# Altium schematic sheet Power_2A_5Vto4VTI.SchDoc
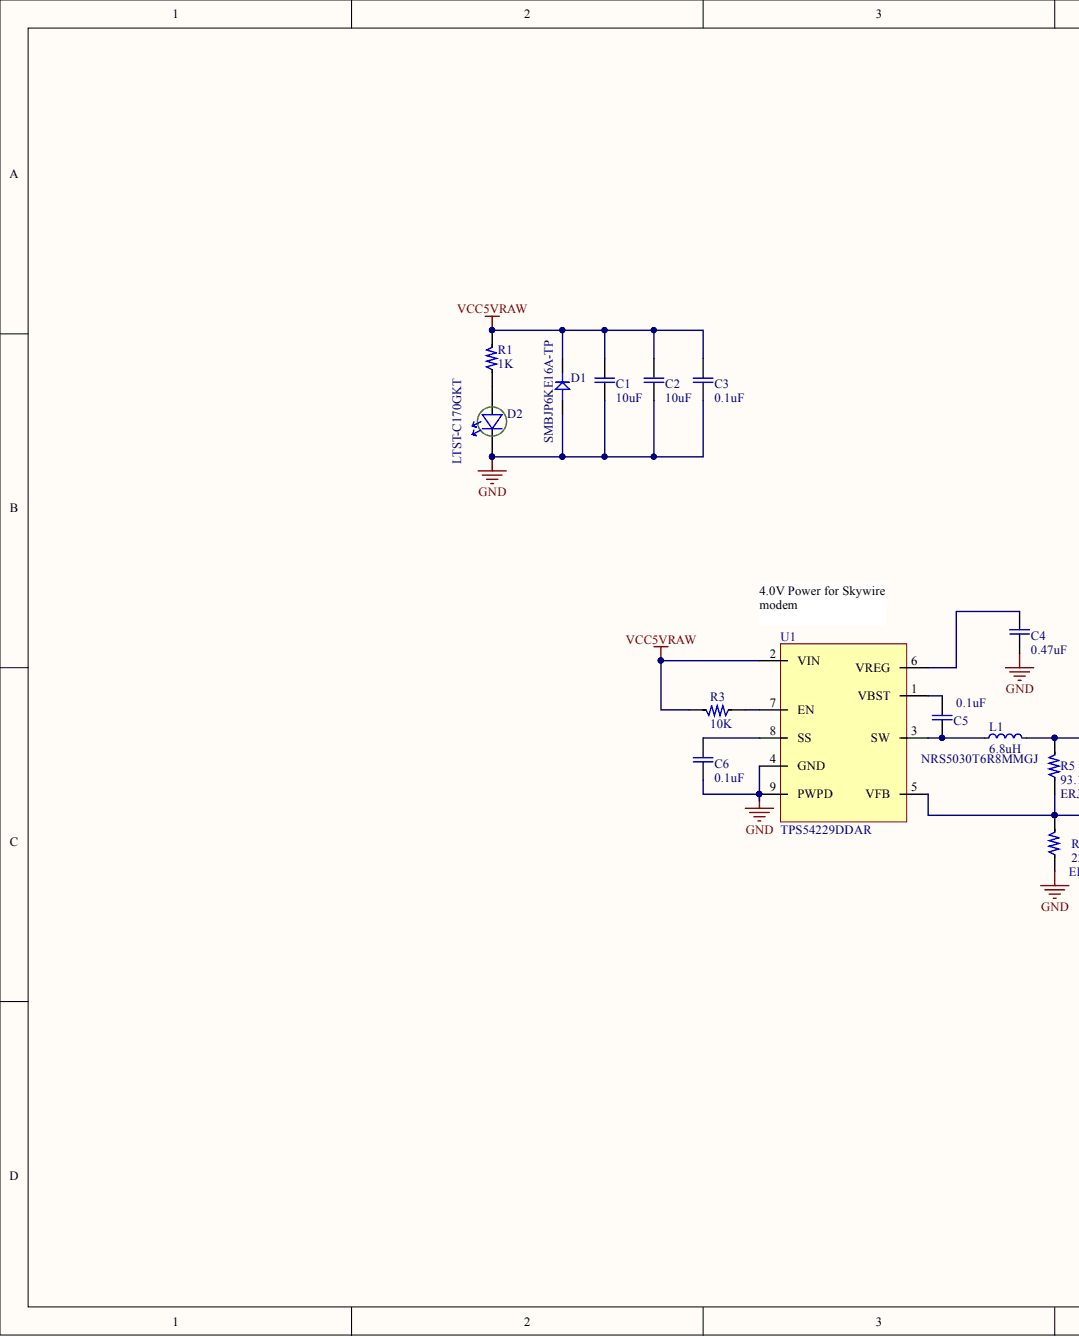
[diagram p0: Power_2A_5Vto4VTI.SchDoc, left]
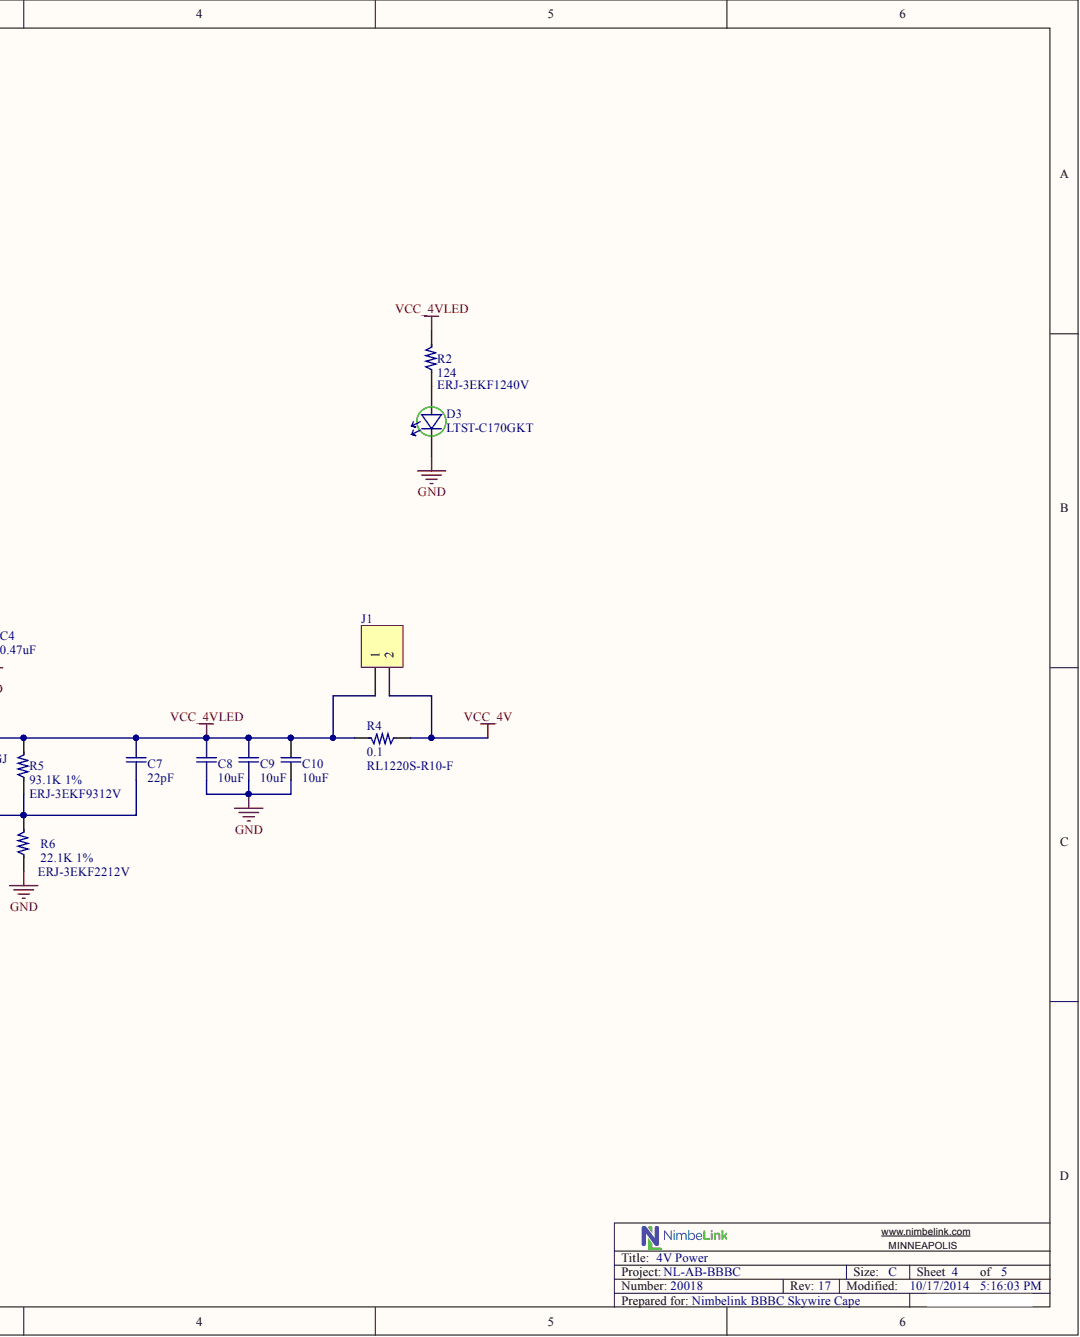
[diagram p1: Power_2A_5Vto4VTI.SchDoc, right]

## Parts
C1  10uF  [0603]
C2  10uF  [0603]
C3  0.1uF  [0603]
C4  0.47uF  [0603]
C5  0.1uF  [0603]
C6  0.1uF  [0603]
C7  22pF  [0603]
C8  10uF  [0603]
C9  10uF  [0603]
C10  10uF  [0603]
D1  Manufacturer Part Number 1: SMBJP6KE16A-TP  [D_SMBJP6KE16A-TP]  (hidden comment: D_TVS DIODE 13.6VWM 22.5VC SMBJ)
D2  Manufacturer Part Number 1: LTST-C170GKT  [D_LED_GREEN]  (hidden comment: D_LED_GREEN)
D3  Manufacturer Part Number 1: LTST-C170GKT  [D_LED_GREEN]  (hidden comment: D_LED_GREEN)
J1  NP  [HDR1X2]  (value not drawn)
L1  6.8uH  [NR5030]
R1  1K  [0603]
R2  124  Manufacturer Part Number 1: ERJ-3EKF1240V  [0603]
R3  10K  [0603]
R4  0.1  Manufacturer Part Number 2: RL1220S-R10-F  [0805]
R5  93.1K 1%  Manufacturer Part Number 1: ERJ-3EKF9312V  [0603]
R6  22.1K 1%  Manufacturer Part Number 1: ERJ-3EKF2212V  [0603]
U1  Manufacturer Part Number 1: TPS54229DDAR  [TPS54229DDA]  (hidden comment: U_TPS54229DDA)

## Nets
GND: C1.2 C2.2 C3.2 C4.1 C6.2 C8.2 C9.2 C10.2 D1.A D2.2 D3.2 R6.1 U1.4(GND) U1.9(PWPD)
VCC5VRAW: C1.1 C2.1 C3.1 D1.K R1.2 R3.2 U1.2(VIN)
VCC_4V: J1.2 R4.1
VCC_4VLED: C7.1 C8.1 C9.1 C10.1 J1.1 L1.2 R2.2 R4.2 R5.2
NetC4_2: C4.2 U1.6(VREG)
NetC5_1: C5.1 U1.1(VBST)
NetC5_2: C5.2 L1.1 U1.3(SW)
NetC6_1: C6.1 U1.8(SS)
NetC7_2: C7.2 R5.1 R6.2 U1.5(VFB)
NetD2_1: D2.1 R1.1
NetD3_1: D3.1 R2.1
NetR3_1: R3.1 U1.7(EN)

## Library data of the parts
C1 C2 C8-C10 = Cap 10uF 10V 10% X5R 0603 CER: Component Kind: Standard; Description: Cap 10uF 10V 10% X5R 0603 CER; Supplier 1: Digi-Key; Supplier Part Number 1: 445-7486-1-ND; Library Name: Nimbelink Altium Lib.SchLib
C3 C5 C6 = Cap 0.1uF 16V 10% X7R 0603 CER: Component Kind: Standard; Description: Cap 0.1uF 16V 10% X7R 0603 CER; Supplier 1: Digi-Key; Supplier Part Number 1: 1276-1005-1-ND; Library Name: Nimbelink Altium Lib.SchLib
C4 = Cap 0.47uF 16V 10% X7R 0603 CER: Component Kind: Standard; Description: Cap 0.47uF 16V 10% X7R 0603 CER; Supplier 1: Digi-Key; Supplier Part Number 1: 1276-1062-1-ND; Library Name: Nimbelink Altium Lib.SchLib
C7 = Cap 22pF 50V 10% X7R 0603 CER: Manufactuer Part Number: 06035C220JAT2A; Component Kind: Standard; Description: Cap 22pF 50V 10% X7R 0603 CER; Supplier 1: Digi-Key; Supplier Part Number 1: 478-6204-1-ND; Library Name: Nimbelink Altium Lib.SchLib
D1 = D_TVS DIODE 13.6VWM 22.5VC SMBJ: Brand: Micro Commercial Components (MCC); Breakdown Voltage: 16.8 V; Clamping Voltage: 22.5 V; Peak Pulse Power Dissipation: 600 W; Peak Surge Current: 27.1 A; Polarity: Unidirectional; Category: TVS Diodes - Transient Voltage Suppressors; Component Kind: Standard; ComponentLink1Description: http://www.mouser.com/ds/2/258/SMBJP6KE6.8(C)A-SMBJP6KE550(C)A(DO-214AA)-220730.pdf; ComponentLink1URL: http://www.mouser.com/ds/2/258/SMBJP6KE6.8(C)A-SMBJP6KE550(C)A(DO-214AA)-220730.pdf; Dimensions: 5.59 mm W x 4.7 mm L x 2.44 mm H; Manufacturer 1: Micro Commercial Components (MCC); Maximum Operating Temperature: + 150 C; Minimum Operating Temperature: - 55 C; Minimum Order Quantity: 1; Mounting Style: SMD/SMT; Package / Case: DO-214AA; Packaging: Reel; Pricing: 1=0.567, 10=0.378, 100=0.211, 1000=0.144, 3000=0.136, 9000=0.127, 24000=0.116, 45000=0.112, 99000=0.108 (AUD); Reeling: True; RoHS: RoHS Compliant; RoHS - Mouser: Y; Series: SMBJP6KE16; Standard Pack Qty: 3000; Stock: 1228; Supplier 1: Mouser; Supplier Part Number 1: 833-SMBJP6KE16A-TP; Termination Style: SMD/SMT; Library Name: Nimbelink Altium Lib.SchLib
D2 D3 = D_LED_GREEN: LED GREEN  SMD; Brand: Lite-On; Forward Current: 20 mA; Forward Voltage: 2.1 V; LED Size: 2 mm x 1.25 mm; Lens Color/Style: Water Clear; Luminous Intensity: 6 mcd; Viewing Angle: 130 deg; Wavelength/Color Temperature: 569 nm; Category: Standard LEDs - SMD; Component Kind: Standard; ComponentLink1Description: http://www.mouser.com/catalog/specsheets/Lite-On-LTST-C170GKT.pdf; ComponentLink1URL: http://www.mouser.com/catalog/specsheets/Lite-On-LTST-C170GKT.pdf; Illumination Color: Green; Manufacturer 1: Lite-On; Maximum Operating Temperature: + 85 C; Minimum Operating Temperature: - 55 C; Minimum Order Quantity: 1; Package / Case: 0805; Packaging: Reel; Pricing: 1=0.513, 10=0.371, 100=0.217, 250=0.154, 500=0.115, 1000=0.089, 3000=0.077, 6000=0.063, 12000=0.058 (AUD); Product Category: SMT LED; Reeling: True; RoHS: RoHS Compliant; RoHS - Mouser: Y; Standard Pack Qty: 3000; Stock: 13646; Supplier 1: Mouser; Supplier Part Number 1: 859-LTST-C170GKT; Library Name: Nimbelink Altium Lib.SchLib
J1 = Header 2: Header, 2-Pin; Component Kind: Standard; LatestRevisionDate: 17-Jul-2002; LatestRevisionNote: Re-released for DXP Platform.; Library Name: Miscellaneous Connectors.IntLib; Signal Integrity: Connector; Publisher: Altium Limited
L1 = L 6.8UH 2.3A 30% SMD NRS5030T6R8MMGJ: INDUCTOR 6.8UH 2.3A 30% SMD5030; Manufacturer 1: Taiyo Yuden; Current - Saturation: 2.5A; DC Resistance (DCR): 52 mOhm; Frequency - Self Resonant: 22MHz; Frequency - Test: 100kHz; Inductance: 6.8µH; Shielding: Shielded; Tolerance: ±20%; Category: Inductors, Coils, Chokes; Component Kind: Standard; ComponentLink1Description: http://www.yuden.co.jp/ut/product/category/inductor/NRS5030T6R8MMGJ.pdf; ComponentLink1URL: http://www.yuden.co.jp/ut/product/category/inductor/NRS5030T6R8MMGJ.pdf; Current Rating: 2.3A; Height - Seated (Max): 0.122" (3.10mm); Manufacturer Part Number 1: NRS5030T6R8MMGJ; Material - Core: -; Mounting Type: Surface Mount; Operating Temperature: -25°C ~ 120°C; Package / Case: Non Standard; Packaging: Cut Tape (CT); Pricing: 1=0.39, 10=0.364, 50=0.3412, 100=0.3185, 500=0.25026, 1000=0.20475, 5000=0.1638 (USD); Q @ Freq: -; RoHS: RoHS Compliant; Size / Dimension: 0.193" L x 0.193" W (4.90mm x 4.90mm); Stock: 1855; Supplier 1: Digi-Key; Supplier Device Package: -; Supplier Part Number 1: 587-3462-1-ND; Type: -; Library Name: Nimbelink Altium Lib.SchLib
R1 = RES 1K 1/10W 1% 0603: Manufacturer 1: Stackpole Electronics Inc; Manufacturer Part Number 1: RNCP0603FTD1K00; Power (Watts): 0.125W, 1/8W; Temperature Coefficient: ±100ppm/°C; Category: Resistors; Component Kind: Standard; ComponentLink1Description: http://www.seielect.com/catalog/SEI-RNCP.pdf; ComponentLink1URL: http://www.seielect.com/catalog/SEI-RNCP.pdf; Composition: Thin Film; Description: RES 1K 1/10W 1% 0603; Features: Anti-Sulfur; Height: 0.020" (0.50mm); Number of Terminations: 2; Package / Case: 0603 (1608 Metric); Packaging: Cut Tape (CT); Pricing: 1=0.05, 10=0.038, 50=0.0208, 100=0.0158, 250=0.01172, 500=0.00864, 1000=0.00576, 2500=0.00486 (USD); Resistance (Ohms): 1k; RoHS: RoHS Compliant; Size / Dimension: 0.059" L x 0.031" W (1.50mm x 0.80mm); Stock: 1735; Supplier 1: Digi-Key; Supplier Device Package: 0603; Supplier Part Number 1: RNCP0603FTD1K00CT-ND; Tolerance: ±1%; Library Name: Nimbelink Altium Lib.SchLib
R2 = RES 124 1/10W 1% 0603: Brand: Panasonic; Case Height: 0.45 mm; Case Length: 1.6 mm; Case Width: 0.8 mm; Power Rating: 100 mW; Resistance: 124 Ohms; Voltage Rating: 75 V; Case Code - in: 0603; Case Code - mm: 1608; Category: Thick Film Resistors - SMD; Component Kind: Standard; ComponentLink1Description: http://industrial.panasonic.com/www-data/pdf/AOA0000/AOA0000CE2.pdf; ComponentLink1URL: http://industrial.panasonic.com/www-data/pdf/AOA0000/AOA0000CE2.pdf; Description: RES 124 1/10W 1% 0603; Manufacturer 1: Panasonic; Minimum Order Quantity: 1; Operating Temperature Range: - 55 C to + 155 C; Package / Case: 0603 (1608 metric); Packaging: Reel; Pricing: 1=0.135, 10=0.020, 100=0.011, 500=0.008, 1000=0.005, 5000=0.003 (AUD); Product: Thick Film Resistors SMD; Reeling: True; RoHS: RoHS Compliant By Exemption; RoHS - Mouser: E; Series: ERJ; Standard Pack Qty: 5000; Stock: 52556; Supplier 1: Mouser; Supplier Part Number 1: 667-ERJ-3EKF1240V; Tolerance: 1 %; Type: Precision Thick Film Resistors SMD; Library Name: Nimbelink Altium Lib.SchLib
R3 = RES 10K 1/10W 1% 0603: Brand: Panasonic; Case Height: 0.45 mm; Case Length: 1.6 mm; Case Width: 0.8 mm; Power Rating: 100 mW; Resistance: 10 kOhms; Temperature Coefficient: 100 PPM / C; Voltage Rating: 75 V; Case Code - in: 0603; Case Code - mm: 1608; Category: Thick Film Resistors - SMD; Component Kind: Standard; ComponentLink1Description: http://industrial.panasonic.com/www-data/pdf/AOA0000/AOA0000CE2.pdf; ComponentLink1URL: http://industrial.panasonic.com/www-data/pdf/AOA0000/AOA0000CE2.pdf; Description: RES 10K 1/10W 1% 0603; Manufacturer 2: Panasonic; Manufacturer Part Number 2: ERJ-3EKF1002V; Minimum Order Quantity: 1; Operating Temperature Range: - 55 C to + 155 C; Package / Case: 0603 (1608 metric); Packaging: Reel; Pricing: 1=0.135, 10=0.019, 100=0.012, 500=0.009, 1000=0.005, 5000=0.004, 25000=0.003 (AUD); Product: Thick Film Resistors SMD; Reeling: True; RoHS: RoHS Compliant By Exemption; RoHS - Mouser: E; Series: ERJ; Standard Pack Qty: 5000; Stock: 1717350; Supplier 1: Digi-Key; Supplier 2: Mouser; Supplier Part Number 1: P10.0KHCT-ND; Supplier Part Number 2: 667-ERJ-3EKF1002V; Tolerance: 1 %; Type: Precision Thick Film Resistors SMD; Library Name: Nimbelink Altium Lib.SchLib
R4 = RES 0.1 1/3W 1% 0805: Brand: Susumu; Case Height: 0.4 mm; Case Length: 2 mm; Case Width: 1.25 mm; Power Rating: 250 mW (1/4 W); Resistance: 100 mOhms; Temperature Coefficient: 200 PPM / C; Case Code - in: 0805; Case Code - mm: 2012; Category: Current Sense Resistors - SMD; Component Kind: Standard; ComponentLink1Description: http://www.susumu.co.jp/product_pdf/all-list/e_15-16.pdf; ComponentLink1URL: http://www.susumu.co.jp/product_pdf/all-list/e_15-16.pdf; Description: RES 0.1 1/3W 1% 0805; Manufacturer 2: Susumu; Minimum Order Quantity: 1; Operating Temperature Range: - 55 C to + 125 C; Package / Case: 0805 (2012 metric); Packaging: Reel; PCB3D: Model Name; Pricing: 1=0.527, 10=0.235, 100=0.105, 1000=0.073, 2000=0.055, 5000=0.055, 10000=0.051, 25000=0.049, 100000=0.047 (AUD); Product: High Precision Current Sensing Metal Strip Resistors; Reeling: True; RoHS: RoHS Compliant; RoHS - Mouser: Y; Series: RL; Standard Pack Qty: 5000; Stock: 46395; Supplier 1: Digi-Key; Supplier 2: Mouser; Supplier Part Number 1: RL12S.10FCT-ND; Supplier Part Number 2: 754-RL1220S-R10-F; Termination Style: SMD/SMT; Tolerance: 1 %; Type: Low Resistance Value Chip Resistors; Library Name: Nimbelink Altium Lib.SchLib
R5 = RES 93.1K 1% 1/10W 0603: Brand: Panasonic; Case Height: 0.45 mm; Case Length: 1.6 mm; Case Width: 0.8 mm; Power Rating: 100 mW; Resistance: 93.1 kOhms; Temperature Coefficient: 100 PPM / C; Voltage Rating: 75 V; Case Code - in: 0603; Case Code - mm: 1608; Category: Thick Film Resistors - SMD; Component Kind: Standard; ComponentLink1Description: http://industrial.panasonic.com/www-data/pdf/AOA0000/AOA0000CE2.pdf; ComponentLink1URL: http://industrial.panasonic.com/www-data/pdf/AOA0000/AOA0000CE2.pdf; Manufacturer 1: Panasonic; Minimum Order Quantity: 1; Operating Temperature Range: - 55 C to + 155 C; Package / Case: 0603 (1608 metric); Packaging: Reel; Pricing: 1=0.135, 10=0.020, 100=0.011, 500=0.008, 1000=0.005, 5000=0.003 (AUD); Product: Thick Film Resistors SMD; Reeling: True; RoHS: RoHS Compliant By Exemption; RoHS - Mouser: E; Series: ERJ; Standard Pack Qty: 5000; Stock: 67507; Supplier 1: Mouser; Supplier Part Number 1: 667-ERJ-3EKF9312V; Tolerance: 1 %; Type: Precision Thick Film Resistors SMD; Library Name: Nimbelink Altium Lib.SchLib
R6 = RES 22.1K 1/10W 1% 0603 SMD: Brand: Panasonic; Case Height: 0.45 mm; Case Length: 1.6 mm; Case Width: 0.8 mm; Power Rating: 100 mW; Resistance: 22.1 kOhms; Temperature Coefficient: 100 PPM / C; Voltage Rating: 75 V; Case Code - in: 0603; Case Code - mm: 1608; Category: Thick Film Resistors - SMD; Component Kind: Standard; ComponentLink1Description: http://industrial.panasonic.com/www-data/pdf/AOA0000/AOA0000CE2.pdf; ComponentLink1URL: http://industrial.panasonic.com/www-data/pdf/AOA0000/AOA0000CE2.pdf; Manufacturer 1: Panasonic; Minimum Order Quantity: 1; Operating Temperature Range: - 55 C to + 155 C; Package / Case: 0603 (1608 metric); Packaging: Reel; Pricing: 1=0.135, 10=0.019, 100=0.012, 500=0.009, 1000=0.005, 5000=0.004, 25000=0.003 (AUD); Product: Thick Film Resistors SMD; Reeling: True; RoHS: RoHS Compliant By Exemption; RoHS - Mouser: E; Series: ERJ; Standard Pack Qty: 5000; Stock: 28853; Supplier 1: Mouser; Supplier Part Number 1: 667-ERJ-3EKF2212V; Tolerance: 1 %; Type: Precision Thick Film Resistors SMD; Library Name: Nimbelink Altium Lib.SchLib
U1 = U_TPS54229DDA: TPS54229DDA 4.5V-18V 2A; Manufacturer 1: Texas Instruments; Frequency - Switching: 700kHz; Number of Outputs: 1; Output Type: Adjustable; PWM Type: Hybrid; Synchronous Rectifier: Yes; Voltage - Input: 4.5 V ~ 18 V; Voltage - Output: 0.76 V ~ 7 V; Category: Integrated Circuits (ICs); Component Kind: Standard; ComponentLink1Description: http://www.ti.com/lit/ds/symlink/tps54229.pdf; ComponentLink1URL: http://www.ti.com/lit/ds/symlink/tps54229.pdf; Current - Output: 2A; Mounting Type: Surface Mount; Operating Temperature: -40°C ~ 85°C; Package / Case: 8-SOIC (0.154", 3.90mm Width) Exposed Pad; Packaging: Cut Tape (CT); Pricing: 1=1.85, 10=1.67, 25=1.4964, 100=1.3456, 250=1.1948, 500=1.044, 750=0.9454, 1000=0.8642 (USD); RoHS: RoHS Compliant; Stock: 1339; Supplier 1: Digi-Key; Supplier Device Package: 8-SO PowerPad; Supplier Part Number 1: 296-29847-1-ND; Type: Step-Down (Buck); Library Name: Nimbelink Altium Lib.SchLib
